# Altium schematic sheet Arak-Mux.SchDoc
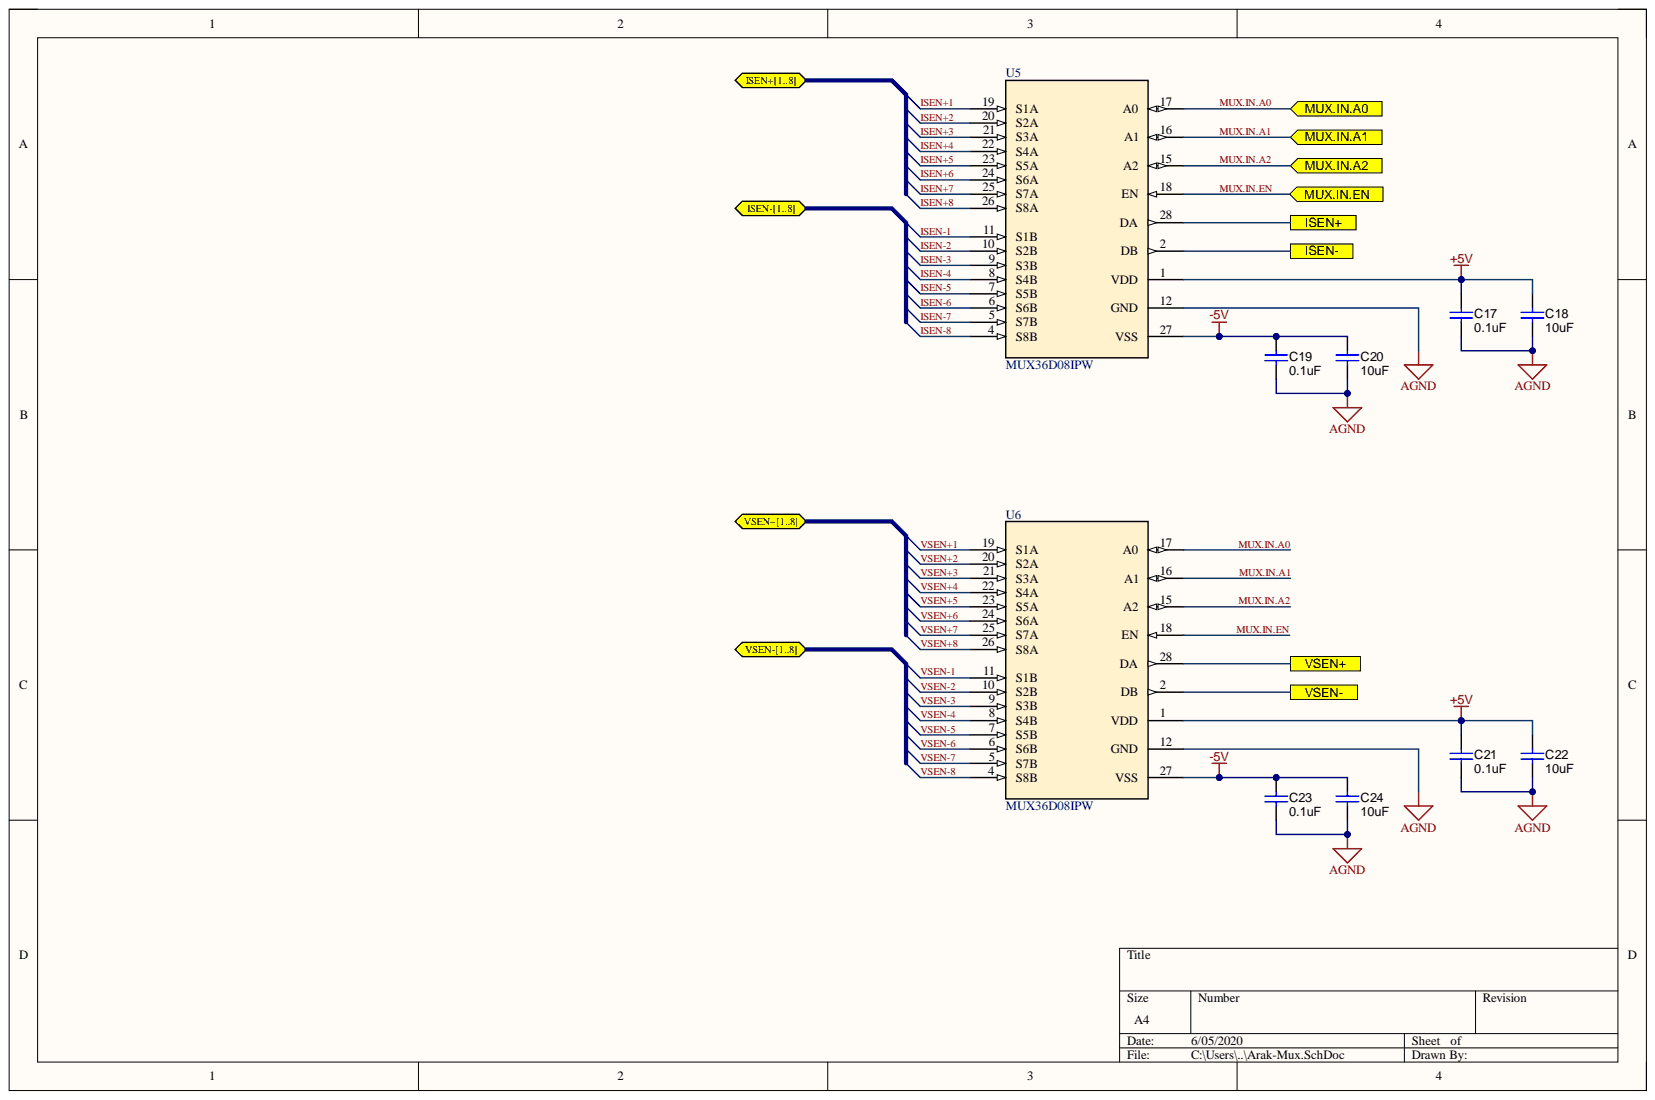
[diagram p0: Arak-Mux.SchDoc]

## Parts
C17  value 0.1uF  [0603]  (hidden comment: Capacitor)
C18  value 10uF  [0805_HV]  (hidden comment: Capacitor)
C19  value 0.1uF  [0603]  (hidden comment: Capacitor)
C20  value 10uF  [0805_HV]  (hidden comment: Capacitor)
C21  value 0.1uF  [0603]  (hidden comment: Capacitor)
C22  value 10uF  [0805_HV]  (hidden comment: Capacitor)
C23  value 0.1uF  [0603]  (hidden comment: Capacitor)
C24  value 10uF  [0805_HV]  (hidden comment: Capacitor)
U5  MUX36D08IPW  [PW0016A_N]
U6  MUX36D08IPW  [PW0016A_N]

## Ports
ISEN+, ISEN+1, ISEN+2, ISEN+3, ISEN+4, ISEN+5, ISEN+6, ISEN+7, ISEN+8, ISEN+[1..8], ISEN-, ISEN-1, ISEN-2, ISEN-3, ISEN-4, ISEN-5, ISEN-6, ISEN-7, ISEN-8, ISEN-[1..8], MUX.IN.A0, MUX.IN.A1, MUX.IN.A2, MUX.IN.EN, VSEN+, VSEN+1, VSEN+2, VSEN+3, VSEN+4, VSEN+5, VSEN+6, VSEN+7, VSEN+8, VSEN+[1..8], VSEN-, VSEN-1, VSEN-2, VSEN-3, VSEN-4, VSEN-5, VSEN-6, VSEN-7, VSEN-8, VSEN-[1..8]

## Nets
+5V: C17.1 C18.1 C21.1 C22.1 U5.1(VDD) U6.1(VDD)
-5V: C19.1 C20.1 C23.1 C24.1 U5.27(VSS) U6.27(VSS)
AGND: C17.2 C18.2 C19.2 C20.2 C21.2 C22.2 C23.2 C24.2 U5.12(GND) U6.12(GND)
ISEN+1: U5.19(S1A)
ISEN+2: U5.20(S2A)
ISEN+3: U5.21(S3A)
ISEN+4: U5.22(S4A)
ISEN+5: U5.23(S5A)
ISEN+6: U5.24(S6A)
ISEN+7: U5.25(S7A)
ISEN+8: U5.26(S8A)
ISEN-1: U5.11(S1B)
ISEN-2: U5.10(S2B)
ISEN-3: U5.9(S3B)
ISEN-4: U5.8(S4B)
ISEN-5: U5.7(S5B)
ISEN-6: U5.6(S6B)
ISEN-7: U5.5(S7B)
ISEN-8: U5.4(S8B)
MUX.IN.A0: U5.17(A0) U6.17(A0) ; port MUX.IN.A0
MUX.IN.A1: U5.16(A1) U6.16(A1) ; port MUX.IN.A1
MUX.IN.A2: U5.15(A2) U6.15(A2) ; port MUX.IN.A2
MUX.IN.EN: U5.18(EN) U6.18(EN) ; port MUX.IN.EN
VSEN+1: U6.19(S1A)
VSEN+2: U6.20(S2A)
VSEN+3: U6.21(S3A)
VSEN+4: U6.22(S4A)
VSEN+5: U6.23(S5A)
VSEN+6: U6.24(S6A)
VSEN+7: U6.25(S7A)
VSEN+8: U6.26(S8A)
VSEN-1: U6.11(S1B)
VSEN-2: U6.10(S2B)
VSEN-3: U6.9(S3B)
VSEN-4: U6.8(S4B)
VSEN-5: U6.7(S5B)
VSEN-6: U6.6(S6B)
VSEN-7: U6.5(S7B)
VSEN-8: U6.4(S8B)
NetU5_2: U5.2(DB)
NetU5_28: U5.28(DA)
NetU6_2: U6.2(DB)
NetU6_28: U6.28(DA)
N00001_1:
N00001_2:
N00001_3:
N00001_4:
N00001_5:
N00001_6:
N00001_7:
N00001_8:
N00002_1:
N00002_2:
N00002_3:
N00002_4:
N00002_5:
N00002_6:
N00002_7:
N00002_8:
N00003_1:
N00003_2:
N00003_3:
N00003_4:
N00003_5:
N00003_6:
N00003_7:
N00003_8:
N00004_1:
N00004_2:
N00004_3:
N00004_4:
N00004_5:
N00004_6:
N00004_7:
N00004_8:

## Library data of the parts
C17 C19 C21 C23 = CMP-0006752-5: CAP, CERM, 0.1 uF, 25 V, +/- 5%, X7R, 0603; Manufacturer: AVX; PartNumber: 06033C104JAT2A; AEC Category: Q200; AEC Qualified: N; Cap_F: 100nF; ESR_ohm: 0.05; SizeArea_mm2: 1.28; SizeAreaWB_mm2: 4.68; SizeX_mm: 1.6; SizeY_mm: 0.8; SizeZ_mm: 1; TaMax_C: 125°C; TaMin_C: -55°C; U/M: EA; Value1: 0.05 ohm; Value2: 25V; AEC Grade: N/A; Cap_Case: 0603; Component Kind: Standard; ComponentLink1Description: Datasheet link; ComponentLink1URL: http://datasheets.avx.com/X7RDielectric.pdf; CompType: Capacitor; LatestRevisionDate: 2017-03-09; Library Name: Altium Vault - TI; MountType: SMT; PackageReference: 0603; Polarized: N; Published: 2008-06-19; RoHS: Y; Supplier 1: Digi-Key; Supplier 2: Mouser; Supplier 3: Farnell; Supplier Part Number 1: 478-3713-1-ND; Supplier Part Number 2: 581-06033C104JAT2A; Supplier Part Number 3: 1740614; Technology: Ceramic; TempCo: X7R; Tolerance_%: 5%; ValueDisplayed: 0.1uF; VDC_V: 25V; Publisher: Texas Instruments
C18 C20 C22 C24 = CMP-0008315-2: CAP, CERM, 10 uF, 10 V, +/- 10%, X7R, 0805; Manufacturer: MuRata; PartNumber: GRM21BR71A106KE51L; AEC Category: Q200; Cap_Case: 0805; Cap_F: 10µF; ESR_ohm: 3m; Polarized: N; SizeArea_mm2: 2.5; SizeAreaWB_mm2: 6.75; SizeX_mm: 2; SizeY_mm: 1.25; SizeZ_mm: 1.45; TaMax_C: 125°C; TaMin_C: -55°C; U/M: EA; Value1: 0.003 ohm; Value2: 10V; AEC Qualified: ?; Component Kind: Standard; ComponentLink1Description: Datasheet link; ComponentLink1URL: http://search.murata.co.jp/Ceramy/PnsearchViewAction.do?HnOFG=ON&HnNFG=ON&SEL_CNT=50&sLang=en&sKey=GRM21BR71A106KE51L&x=9&y=9&search=startwith; CompType: Capacitor; LatestRevisionDate: 2017-03-09; Library Name: Altium Vault - TI; MountType: SMT; PackageReference: 0805; Published: 2008-06-19; RoHS: Y; Supplier 1: Digi-Key; Supplier 2: Mouser; Supplier 3: Farnell; Supplier Part Number 1: 490-3905-1-ND; Supplier Part Number 2: 81-GRM21BR71A106KE51; Supplier Part Number 3: 1828828; Technology: Ceramic; TempCo: X7R; Tolerance_%: 10%; ValueDisplayed: 10uF; VDC_V: 10V; Publisher: Texas Instruments
U5 U6 = MUX36D08IPW: No Description Available; Manufacturer: Texas Instruments; PartNumber: MUX36S08IPWR; Component Kind: Standard; Library Name: 2020-02-03_05-08-55.SchLib; RefDes: RefDes; Type: DEV; Value: Value; Copyright: Copyright (C) 2018 Accelerated Designs. All rights reserved
